# Altium schematic sheet 05_GPIO.SchDoc
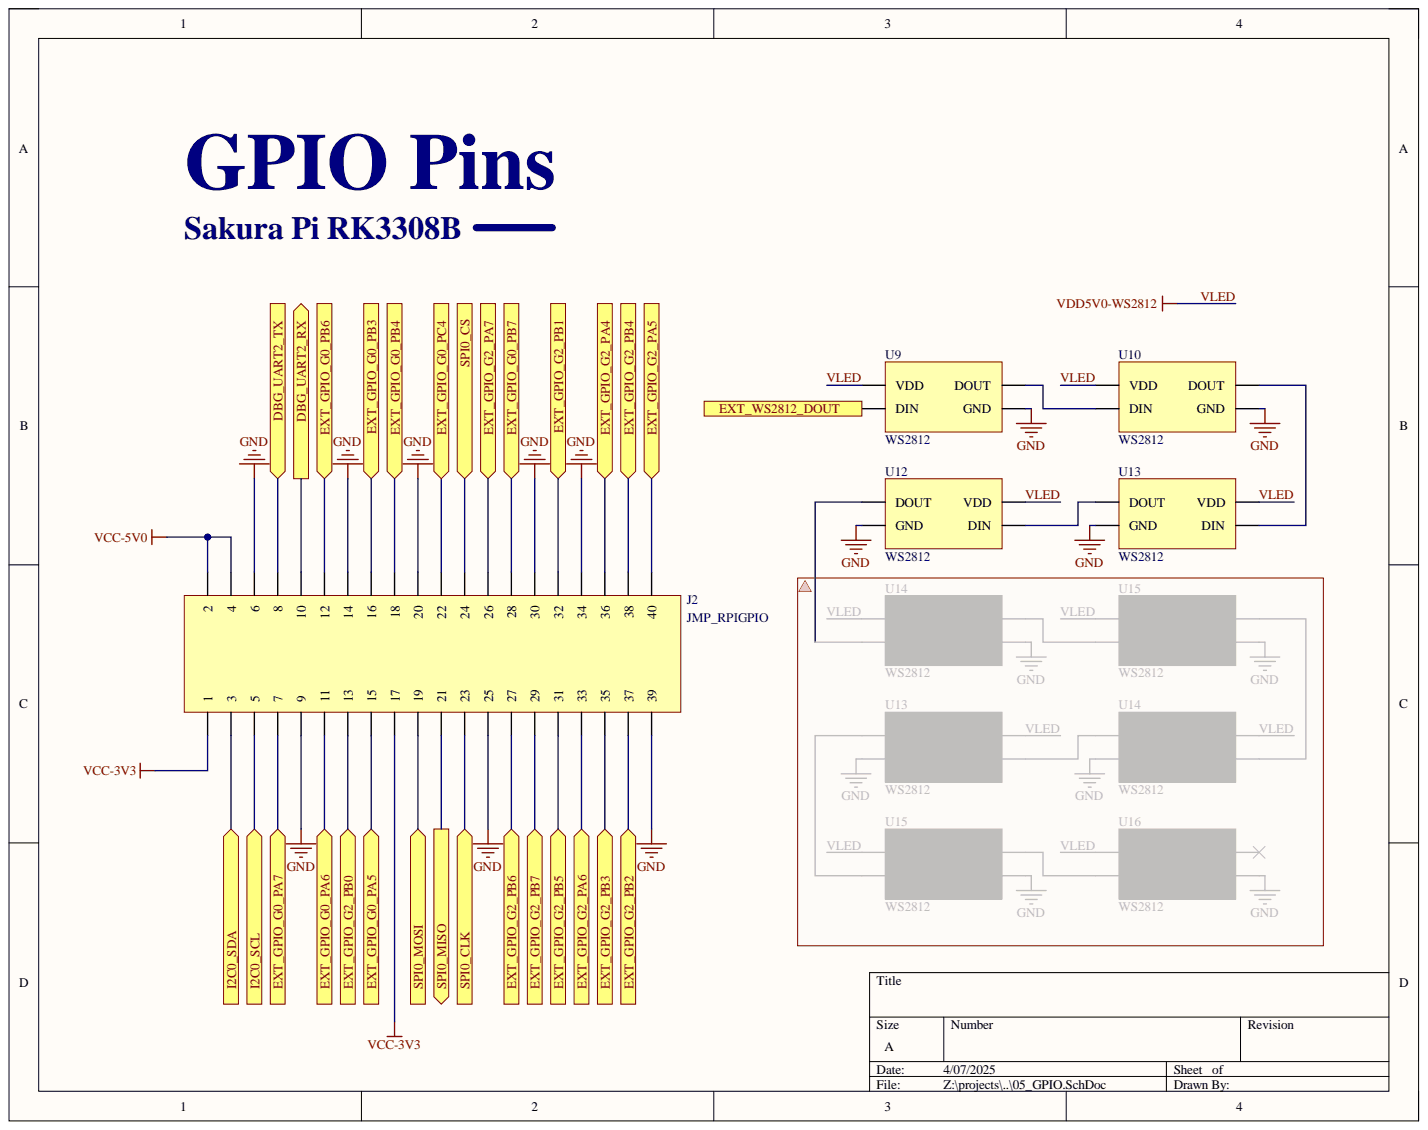
[diagram p0: 05_GPIO.SchDoc]

## Parts
J2  JMP_RPIGPIO  [JMP_RPIGPIO]
U9  WS2812  [WS2812_1010]
U10  WS2812  [WS2812_1010]
U12  WS2812  [WS2812_1010]
U13  WS2812  [WS2812_1010]

## Ports
DBG_UART2_RX, DBG_UART2_TX, EXT_GPIO_G0_PA5, EXT_GPIO_G0_PA6, EXT_GPIO_G0_PA7, EXT_GPIO_G0_PB3, EXT_GPIO_G0_PB4, EXT_GPIO_G0_PB6, EXT_GPIO_G0_PB7, EXT_GPIO_G0_PC4, EXT_GPIO_G2_PA4, EXT_GPIO_G2_PA5, EXT_GPIO_G2_PA6, EXT_GPIO_G2_PA7, EXT_GPIO_G2_PB0, EXT_GPIO_G2_PB1, EXT_GPIO_G2_PB2, EXT_GPIO_G2_PB3, EXT_GPIO_G2_PB4, EXT_GPIO_G2_PB5, EXT_GPIO_G2_PB6, EXT_GPIO_G2_PB7, EXT_WS2812_DOUT, I2C0_SCL, I2C0_SDA, SPI0_CLK, SPI0_CS, SPI0_MISO, SPI0_MOSI

## Nets
GND: J2.6 J2.9 J2.14 J2.20 J2.25 J2.30 J2.34 J2.39 U9.2(GND) U10.2(GND) U12.2(GND) U13.2(GND)
VCC-3V3: J2.1 J2.17
VCC-5V0: J2.2 J2.4
DBG_UART2_RX: J2.10 ; port DBG_UART2_RX
DBG_UART2_TX: J2.8 ; port DBG_UART2_TX
EXT_GPIO_G0_PA5: J2.15 ; port EXT_GPIO_G0_PA5
EXT_GPIO_G0_PA6: J2.11 ; port EXT_GPIO_G0_PA6
EXT_GPIO_G0_PA7: J2.7 ; port EXT_GPIO_G0_PA7
EXT_GPIO_G0_PB3: J2.16 ; port EXT_GPIO_G0_PB3
EXT_GPIO_G0_PB4: J2.18 ; port EXT_GPIO_G0_PB4
EXT_GPIO_G0_PB6: J2.12 ; port EXT_GPIO_G0_PB6
EXT_GPIO_G0_PB7: J2.28 ; port EXT_GPIO_G0_PB7
EXT_GPIO_G0_PC4: J2.22 ; port EXT_GPIO_G0_PC4
EXT_GPIO_G2_PA4: J2.36 ; port EXT_GPIO_G2_PA4
EXT_GPIO_G2_PA5: J2.40 ; port EXT_GPIO_G2_PA5
EXT_GPIO_G2_PA6: J2.33 ; port EXT_GPIO_G2_PA6
EXT_GPIO_G2_PA7: J2.26 ; port EXT_GPIO_G2_PA7
EXT_GPIO_G2_PB0: J2.13 ; port EXT_GPIO_G2_PB0
EXT_GPIO_G2_PB1: J2.32 ; port EXT_GPIO_G2_PB1
EXT_GPIO_G2_PB2: J2.37 ; port EXT_GPIO_G2_PB2
EXT_GPIO_G2_PB3: J2.35 ; port EXT_GPIO_G2_PB3
EXT_GPIO_G2_PB4: J2.38 ; port EXT_GPIO_G2_PB4
EXT_GPIO_G2_PB5: J2.31 ; port EXT_GPIO_G2_PB5
EXT_GPIO_G2_PB6: J2.27 ; port EXT_GPIO_G2_PB6
EXT_GPIO_G2_PB7: J2.29 ; port EXT_GPIO_G2_PB7
EXT_WS2812_DOUT: U9.3(DIN) ; port EXT_WS2812_DOUT
I2C0_SCL: J2.5 ; port I2C0_SCL
I2C0_SDA: J2.3 ; port I2C0_SDA
SPI0_CLK: J2.23 ; port SPI0_CLK
SPI0_CS: J2.24 ; port SPI0_CS
SPI0_MISO: J2.21 ; port SPI0_MISO
SPI0_MOSI: J2.19 ; port SPI0_MOSI
VLED: U9.4(VDD) U10.4(VDD) U12.4(VDD) U13.4(VDD)
NetU9_1: U9.1(DOUT) U10.3(DIN)
NetU10_1: U10.1(DOUT) U13.3(DIN)
NetU12_3: U12.3(DIN) U13.1(DOUT)

Not connected: U12 1(DOUT)

## Library data of the parts
J2 = JMP_RPIGPIO: Component Kind: Standard; Library Name: rk3308b.SchLib
U9 U10 U12 U13 = WS2812: Component Kind: Standard; Library Name: rk3308b.SchLib
